# Altium schematic sheet CAN.SchDoc(CAN)
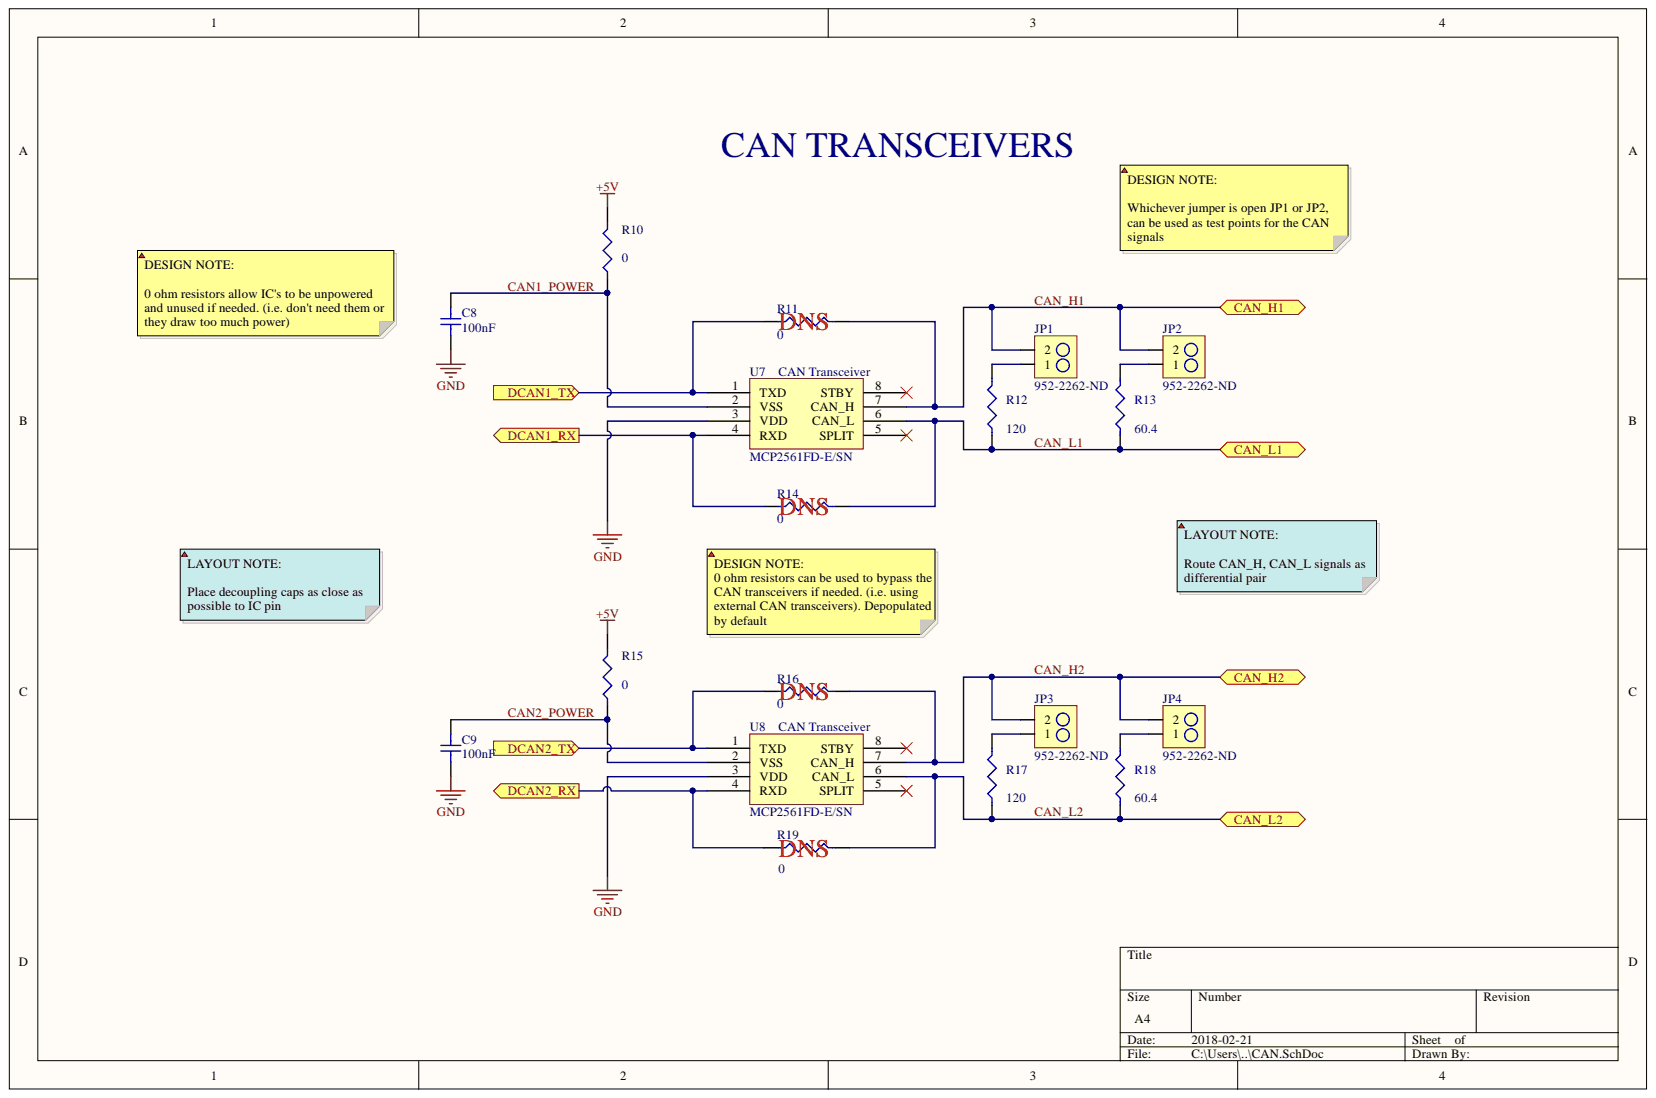
[diagram p0: CAN.SchDoc]

## Parts
C8  100nF  [C0805]
C9  100nF  [C0805]
JP1  952-2262-ND  [2PIN_HEADER]
JP2  952-2262-ND  [2PIN_HEADER]
JP3  952-2262-ND  [2PIN_HEADER]
JP4  952-2262-ND  [2PIN_HEADER]
R10  0  [R0805]
R11  0  [R0805]
R12  120  [R0805]
R13  60.4  [R0805]
R14  0  [R0805]
R15  0  [R0805]
R16  0  [R0805]
R17  120  [R0805]
R18  60.4  [R0805]
R19  0  [R0805]
U7  MCP2561FD-E/SN  Logic Function: Transceiver  Interface: CAN  [8-SOIC_MCP2561]
U8  MCP2561FD-E/SN  Logic Function: Transceiver  Interface: CAN  [8-SOIC_MCP2561]

## Ports
CAN_H1, CAN_H2, CAN_L1, CAN_L2, DCAN1_RX, DCAN1_TX, DCAN2_RX, DCAN2_TX

## Nets
+5V: R10.2 R15.2
GND: C8.1 C9.1 U7.3(VDD) U8.3(VDD)
CAN1_POWER: C8.2 R10.1 U7.2(VSS)
CAN2_POWER: C9.2 R15.1 U8.2(VSS)
CAN_H1: JP1.2 JP2.2 R11.2 U7.7(CAN_H) ; port CAN_H1
CAN_H2: JP3.2 JP4.2 R16.2 U8.7(CAN_H) ; port CAN_H2
CAN_L1: R12.1 R13.1 R14.2 U7.6(CAN_L) ; port CAN_L1
CAN_L2: R17.1 R18.1 R19.2 U8.6(CAN_L) ; port CAN_L2
DCAN1_RX: R14.1 U7.4(RXD) ; port DCAN1_RX
DCAN1_TX: R11.1 U7.1(TXD) ; port DCAN1_TX
DCAN2_RX: R19.1 U8.4(RXD) ; port DCAN2_RX
DCAN2_TX: R16.1 U8.1(TXD) ; port DCAN2_TX
NetJP1_1: JP1.1 R12.2
NetJP2_1: JP2.1 R13.2
NetJP3_1: JP3.1 R17.2
NetJP4_1: JP4.1 R18.2

Not connected: U7 5(SPLIT) 8(STBY); U8 5(SPLIT) 8(STBY)

## Library data of the parts
C8 C9 = GRM21B7U1A104JA01L: CAP MLCC 100nF 10Volts U2J 5%; Manufacturer: Murata; Voltage Rating (DC): 10V; Width: 0.049inch; Voltage Rating: 10V; Tolerance: 5%; Thickness: 0.053inch; Termination: SMD/SMT; Supplier Part Number 1: 490-11592-6-ND; Supplier 1: Digi-Key; Stock 1: 6000; Radiation Hardening: No; Pricing 1: 1=0.46, 10=0.32, 100=0.192, 500=0.144, 1000=0.1216 (USD); Packaging: Tape and Reel; Package Quantity: 3000; Mount: Surface Mount; Min Operating Temperature: -55°C; Max Operating Temperature: 125°C; Manufacturer Part Number: GRM21B7U1A104JA01L; Length: 2mm; Height: 1.25mm; Dielectric: U2J; Depth: 1.25mm; ComponentLink3URL: https://datasheet.ciiva.com/11618/product-11618924.pdf; ComponentLink3Description: https://datasheet.ciiva.com/11618/product-11618924.pdf; ComponentLink2URL: https://datasheet.ciiva.com/17451/product-17451845.pdf; ComponentLink2Description: https://datasheet.ciiva.com/17451/product-17451845.pdf; ComponentLink1URL: https://datasheet.ciiva.com/5424/product-5424660.pdf; ComponentLink1Description: https://datasheet.ciiva.com/5424/product-5424660.pdf; Component Kind: Standard; Case Code (Metric): 2012; Case Code (Imperial): 0805; Case/Package: 0805; Capacitance: 100nF; Library Name: PHANTOM_LIBRARY.SchLib
JP1-JP4 = M20-9990246: CONN_HeaderPins2pos Headers & Wire Housings 02 SIL VERTICAL PIN HEADER TIN; Manufacturer: Harwin; Voltage Rating (AC): 750V; Pitch: 2.54mm; Number of Positions: 2; Max Current Rating: 3A; Insulation Resistance: 10GR; Gender: Male; Contact Resistance: 30mR; Connector Type: Male Pin; Termination: Solder; Supplier Part Number 1: 952-2262-ND; Supplier 1: Digi-Key; Stock 1: 53577; REACH SVHC: No SVHC; Radiation Hardening: No; Pricing 1: 1=0.11, 10=0.099, 100=0.0609, 500=0.0504, 1000=0.0441, 5000=0.0378, 10000=0.0368 (USD); Packaging: Bulk; Package Quantity: 1000; Orientation: Straight; Number of Rows: 1; Number of Contacts: 2; Min Operating Temperature: -40°C; Max Operating Temperature: 105°C; Manufacturer Part Number: M20-9990246; Length: 5.08mm; Housing Material: Nylon; Housing Colour: Black; Depth: 2.54mm; Current Rating: 3A; Contact Plating: Tin; Contact Mating Length: 0.24inch; Contact Material: Bronze,Brass; ComponentLink5URL: https://datasheet.ciiva.com/26852/getdatasheetpartid-384170-26852203.pdf; ComponentLink5Description: https://datasheet.ciiva.com/26852/getdatasheetpartid-384170-26852203.pdf; ComponentLink4URL: https://datasheet.ciiva.com/22660/1830818-22660571.pdf; ComponentLink4Description: https://datasheet.ciiva.com/22660/1830818-22660571.pdf; ComponentLink3URL: https://datasheet.ciiva.com/11745/0900766b81034e61-11745755.pdf; ComponentLink3Description: https://datasheet.ciiva.com/11745/0900766b81034e61-11745755.pdf; ComponentLink2URL: https://datasheet.ciiva.com/6833/m20-999-6833368.pdf; ComponentLink2Description: https://datasheet.ciiva.com/6833/m20-999-6833368.pdf; ComponentLink1URL: https://datasheet.ciiva.com/8046/315376-8046930.pdf; ComponentLink1Description: https://datasheet.ciiva.com/8046/315376-8046930.pdf; Component Kind: Standard; Colour: Black; Case/Package: SIL; Library Name: PHANTOM_LIBRARY.SchLib
R10 R11 R14-R16 R19 = RC0805JR-070RL: RES SMD 0 OHM 1/8W 0805; Manufacturer: Yageo; Voltage Rating (DC): 150V; Tolerance: 5%; Temperature Coefficient: 200ppm/°C; Resistance: 0mR; Power Rating: 125mW; Width: 1.25mm; Voltage Rating: 150V; Termination: SMD/SMT; Supplier Part Number 1: 311-0.0ARDKR-ND; Supplier 1: Digi-Key; Stock 1: 5922085; REACH SVHC: Unknown; Radiation Hardening: No; Pricing 1: 1=0.1, 10=0.018, 25=0.0128, 50=0.0096, 100=0.0071, 250=0.0054, 500=0.0044, 1000=0.0032 (USD); Packaging: Tape and Reel; Number of Pins: 2; Min Operating Temperature: -55°C; Military Standard: Not; Max Operating Temperature: 155°C; Manufacturer Part Number: RC0805JR-070RL; Length: 2mm; Lead Free: Lead Free; Height: 0.5mm; Depth: 1.25mm; Contact Plating: Tin,Matte; Composition: Thick Film; ComponentLink5URL: https://datasheet.ciiva.com/15190/getdatasheetpartid-330685-15190028.pdf; ComponentLink5Description: https://datasheet.ciiva.com/15190/getdatasheetpartid-330685-15190028.pdf; ComponentLink4URL: https://datasheet.ciiva.com/7941/1738694-7941863.pdf; ComponentLink4Description: https://datasheet.ciiva.com/7941/1738694-7941863.pdf; ComponentLink3URL: https://datasheet.ciiva.com/11819/0900766b8106beab-11819430.pdf; ComponentLink3Description: https://datasheet.ciiva.com/11819/0900766b8106beab-11819430.pdf; ComponentLink2URL: https://datasheet.ciiva.com/7941/1738694-7941862.pdf; ComponentLink2Description: https://datasheet.ciiva.com/7941/1738694-7941862.pdf; ComponentLink1URL: https://datasheet.ciiva.com/7941/1738694-7941861.pdf; ComponentLink1Description: https://datasheet.ciiva.com/7941/1738694-7941861.pdf; Component Kind: Standard; Case Code (Metric): 2012; Case Code (Imperial): 0805; Case/Package: 0805; Library Name: PHANTOM_LIBRARY.SchLib
R12 R17 = RC0805FR-07120RL: RES SMD 120 OHM 1% 1/8W 0805; Manufacturer: Yageo; Voltage Rating: 150V; Temperature Coefficient: 100ppm/°C; Resistance: 120R; Power Rating: 125mW; Width: 0.049inch; Tolerance: 1%; Termination: SMD/SMT; Supplier Part Number 1: 311-120CRCT-ND; Supplier 1: Digi-Key; Stock 1: 632664; REACH SVHC: Unknown; Pricing 1: 1=0.1, 10=0.022, 100=0.0088, 1000=0.004, 2500=0.0034 (USD); Packaging: Tape and Reel; Package Quantity: 1; Number of Terminations: 2; Min Operating Temperature: -55°C; Military Standard: Not; Max Power Dissipation: 125mW; Max Operating Temperature: 155°C; Manufacturer Part Number: RC0805FR-07120RL; Length: 2mm; Lead Free: Lead Free; Height: 0.5mm; Depth: 1.25mm; Composition: Thick Film; ComponentLink8URL: https://datasheet.ciiva.com/16964/rc0805-16964606.pdf; ComponentLink8Description: https://datasheet.ciiva.com/16964/rc0805-16964606.pdf; ComponentLink7URL: https://datasheet.ciiva.com/7941/444164-7941692.pdf; ComponentLink7Description: https://datasheet.ciiva.com/7941/444164-7941692.pdf; ComponentLink6URL: https://datasheet.ciiva.com/7941/444164-7941691.pdf; ComponentLink6Description: https://datasheet.ciiva.com/7941/444164-7941691.pdf; ComponentLink5URL: https://datasheet.ciiva.com/11819/0900766b8106beab-11819786.pdf; ComponentLink5Description: https://datasheet.ciiva.com/11819/0900766b8106beab-11819786.pdf; ComponentLink4URL: https://datasheet.ciiva.com/12558/rc0805-257173-12558661.pdf; ComponentLink4Description: https://datasheet.ciiva.com/12558/rc0805-257173-12558661.pdf; ComponentLink3URL: https://datasheet.ciiva.com/2491/pyu-r-int-thick-7-2491587.pdf; ComponentLink3Description: https://datasheet.ciiva.com/2491/pyu-r-int-thick-7-2491587.pdf; ComponentLink2URL: https://datasheet.ciiva.com/2491/pyu-r-int-thick-7-2491586.pdf; ComponentLink2Description: https://datasheet.ciiva.com/2491/pyu-r-int-thick-7-2491586.pdf; ComponentLink1URL: https://datasheet.ciiva.com/2491/pyu-r-int-thick-7-2491585.pdf; ComponentLink1Description: https://datasheet.ciiva.com/2491/pyu-r-int-thick-7-2491585.pdf; Component Kind: Standard; Case Code (Metric): 2012; Case Code (Imperial): 0805; Case/Package: 0805; Library Name: PHANTOM_LIBRARY.SchLib
R13 R18 = RC0805FR-0760R4L: RES SMD 60.4 OHM 1% 1/8W 0805; Manufacturer: Yageo; Voltage Rating (DC): 150V; Temperature Coefficient: 100ppm/°C; Resistance: 60.4R; Power Rating: 125mW; Width: 0.049inch; Voltage Rating: 150V; Tolerance: 1%; Termination: SMD/SMT; Supplier Part Number 1: 311-60.4CRDKR-ND; Supplier 1: Digi-Key; Stock 1: 189238; Radiation Hardening: No; Pricing 1: 1=0.1, 10=0.022, 100=0.0088, 1000=0.004, 2500=0.0034 (USD); Packaging: Tape and Reel; Package Quantity: 1; Number of Terminations: 2; Min Operating Temperature: -55°C; Military Standard: Not; Max Power Dissipation: 125mW; Max Operating Temperature: 155°C; Manufacturer Part Number: RC0805FR-0760R4L; Length: 0.079inch; Lead Free: Lead Free; Height: 0.024inch; Depth: 1.25mm; Contact Plating: Tin,Lead,Matte; Composition: Thick Film; ComponentLink3URL: https://datasheet.ciiva.com/2457/pyu-r-int-thick-7-2457215.pdf; ComponentLink3Description: https://datasheet.ciiva.com/2457/pyu-r-int-thick-7-2457215.pdf; ComponentLink2URL: https://datasheet.ciiva.com/2457/pyu-r-int-thick-7-2457217.pdf; ComponentLink2Description: https://datasheet.ciiva.com/2457/pyu-r-int-thick-7-2457217.pdf; ComponentLink1URL: https://datasheet.ciiva.com/2457/pyu-r-int-thick-7-2457216.pdf; ComponentLink1Description: https://datasheet.ciiva.com/2457/pyu-r-int-thick-7-2457216.pdf; Component Kind: Standard; Case Code (Metric): 2012; Case Code (Imperial): 0805; Case/Package: 0805; Library Name: PHANTOM_LIBRARY.SchLib
U7 U8 = MCP2561FD-E/SN: IC CAN Bus, Transceiver, CAN, 1, 1, 4.5 V, 5.5 V, SOIC; Manufacturer: Microchip; Receiver Hysteresis: 200mV; Operating Supply Current: 70mA; Number of Transmit Buffers: 1; Min Supply Voltage: 4.5V; Max Supply Voltage: 5.5V; Data Rate: 8Mbps; Width: 3.9mm; Weight: 0.019048oz; Supplier 1: Digi-Key; Stock 1: 1072; REACH SVHC: No SVHC; Pricing 1: 1=0.97, 25=0.8084, 100=0.735 (USD); Packaging: Rail/Tube; Package Quantity: 100; Number of Transceivers: 1; Number of Receivers: 1; Number of Receive Buffers: 1; Number of Pins: 8; Number of Drivers: 1; Min Operating Temperature: -40°C; Max Supply Current: 70mA; Max Operating Temperature: 125°C; Manufacturer Part Number: MCP2561FD-E/SN; Length: 4.9mm; Lead Free: Lead Free; Height: 1.5mm; ComponentLink4URL: https://datasheet.ciiva.com/48473/20005284a-48473177.pdf; ComponentLink4Description: https://datasheet.ciiva.com/48473/20005284a-48473177.pdf; ComponentLink3URL: https://datasheet.ciiva.com/46406/1821190-46406056.pdf; ComponentLink3Description: https://datasheet.ciiva.com/46406/1821190-46406056.pdf; ComponentLink2URL: https://datasheet.ciiva.com/46406/1821190-46406024.pdf; ComponentLink2Description: https://datasheet.ciiva.com/46406/1821190-46406024.pdf; ComponentLink1URL: https://datasheet.ciiva.com/48512/mcp2561fd-eng-tds-48512069.pdf; ComponentLink1Description: https://datasheet.ciiva.com/48512/mcp2561fd-eng-tds-48512069.pdf; Component Kind: Standard; Case/Package: SOIC; Library Name: PHANTOM_LIBRARY.SchLib
